# Altium schematic sheet AutoProgramCircuit.SchDoc
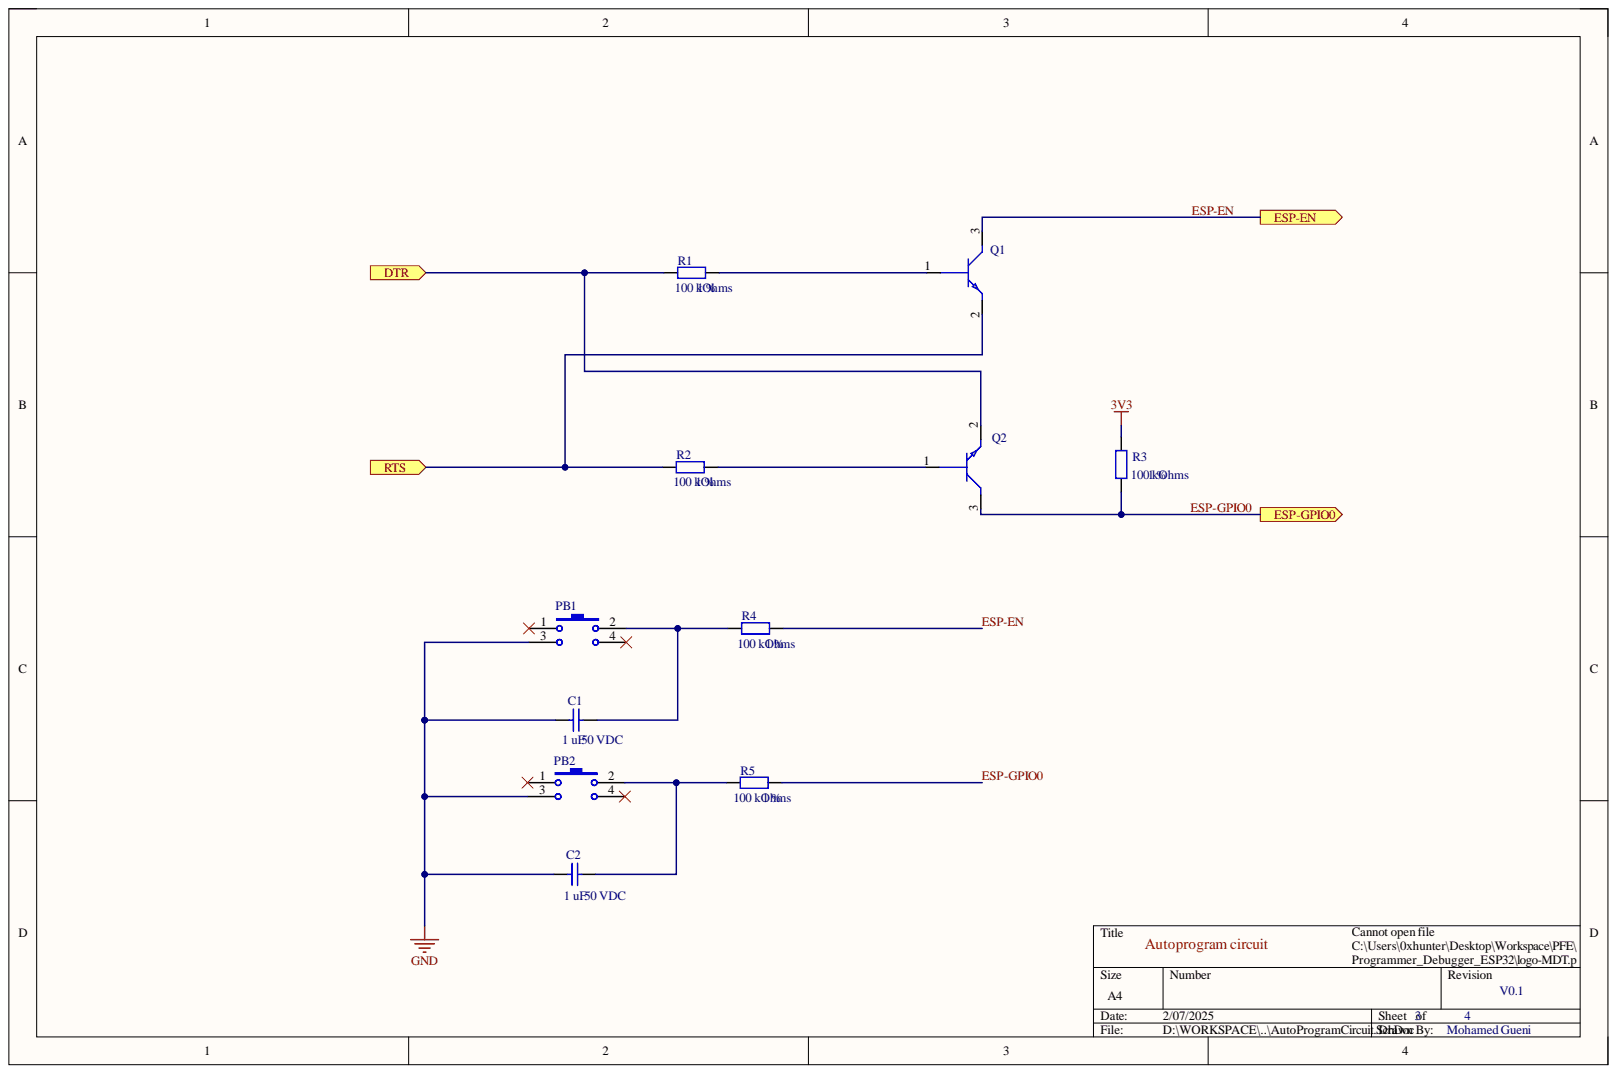
[diagram p0: AutoProgramCircuit.SchDoc]

## Parts
C1  Capacitor  [CAP-1210]  (value not drawn)
C2  Capacitor  [CAP-1210]  (value not drawn)
PB1  SW-PB  [Through Hole]  (value not drawn)
PB2  SW-PB  [Through Hole]  (value not drawn)
Q1  BJT  [SOT95P245X112-3N]  (value not drawn)
Q2  BJT  [SOT95P245X112-3N]  (value not drawn)
R1  TOL: 1 %  Value 1: 100 kOhms  [R0603]  (hidden comment: Resistor)
R2  TOL: 1 %  Value 1: 100 kOhms  [R0603]  (hidden comment: Resistor)
R3  Value 1: 100 kOhms  [R0603]  (hidden comment: Resistor)
R4  TOL: 1 %  Value 1: 100 kOhms  [R0603]  (hidden comment: Resistor)
R5  TOL: 1 %  Value 1: 100 kOhms  [R0603]  (hidden comment: Resistor)

## Ports
DTR, ESP-EN, ESP-GPIO0, RTS

## Nets
3V3: R3.2
GND: C1.1 C2.1 PB1.3 PB2.3
DTR: Q2.2 R1.1 ; port DTR
ESP-EN: Q1.3 R4.2 ; port ESP-EN
ESP-GPIO0: Q2.3 R3.1 R5.2 ; port ESP-GPIO0
RTS: Q1.2 R2.1 ; port RTS
NetC1_2: C1.2 PB1.2 R4.1
NetC2_2: C2.2 PB2.2 R5.1
NetQ1_1: Q1.1 R1.2
NetQ2_1: Q2.1 R2.2

Not connected: PB1 1 4; PB2 1 4

## Library data of the parts
C1 C2 = C-000000005: Database Table Name: Capacitors; DES: Multilayer Ceramic Capacitors MLCC - SMD/SMT 50V 1uF X7R 1210 10%; FTP: 1210; MAN: TAIYO YUDEN; MANREF: UMK325B7105KH-T; NOTE: _; SUP: Mouser; SUPREF: 963-UMK325B7105KH-T; TOL: 10 %; Value 1: 1 uF; Value 2: 50 VDC; Component Kind: Standard; Description: Capacitor; Library Name: DATABASE.DbLib; Part Number: C-000000005
PB1 PB2 = SW-000000001: Database Table Name: Switches; DES: Tactile Switches 50 mAmps at 12 Volts; MAN: ALPS   Gravitech  Gravitech; MANREF: SKHHDHA010; SUP: Mouser; SUPREF: 688-SKHHDHA010; Component Kind: Standard; DataSheet: https://eu.mouser.com/datasheet/2/15/SKHH-1370651.pdf; LatestRevisionDate: 17-Jul-2002; LatestRevisionNote: Re-released for DXP Platform.; Library Name: DATABASE.DbLib; N°: SW-000000001; PackageDescription: Switch; 2 Leads; PackageReference: SPST-2; Published: 24-Mar-1999; Publisher: Altium Limited
Q1 Q2 = Q-000000003: Database Table Name: Transistors; DES: MMSS8050-H-TP; FTP: 5 V; MAN: Bipolar Transistors - BJT 625mW, 25V, 1500mA; MANREF: Micro Commercial Components (MCC); NOTE: NPN; SUP: 1.5 A; SUPREF: Bipolar; TOL: SOT-23-3; Value 1: Mouser; Value 2: 833-MMSS8050-H-TP; Component Kind: Standard; DataSheet: https://eu.mouser.com/datasheet/2/258/MMSS8050(SOT-23)-276387.pdf; Library Name: DATABASE.DbLib; Part Number: Q-000000003
R1 R2 R4 R5 = R-000000002: Database Table Name: Resistors; DES: Thick Film Resistors - SMD 1/10watt 100Kohms 1%; FTP: 0603; MAN: Vishay; MANREF: CRCW0603100KFKEA; SUP: Mouser; SUPREF: 71-CRCW0603-100K-E3; Value 2: 100 mW; Component Kind: Standard; Description: Resistor; Library Name: DATABASE.DbLib; NOTE: -; Part Number: R-000000002
R3 = R-000000002: Database Table Name: Resistors; DES: Thick Film Resistors - SMD 1/10watt 100Kohms 1%; FTP: 0603; MAN: Vishay; MANREF: CRCW0603100KFKEA; SUP: Mouser; SUPREF: 71-CRCW0603-100K-E3; TOL: 1 %; Value 2: 100 mW; Component Kind: Standard; Description: Resistor; Library Name: DATABASE.DbLib; NOTE: -; Part Number: R-000000002
